# Altium schematic sheet Page3_MCU.SchDoc
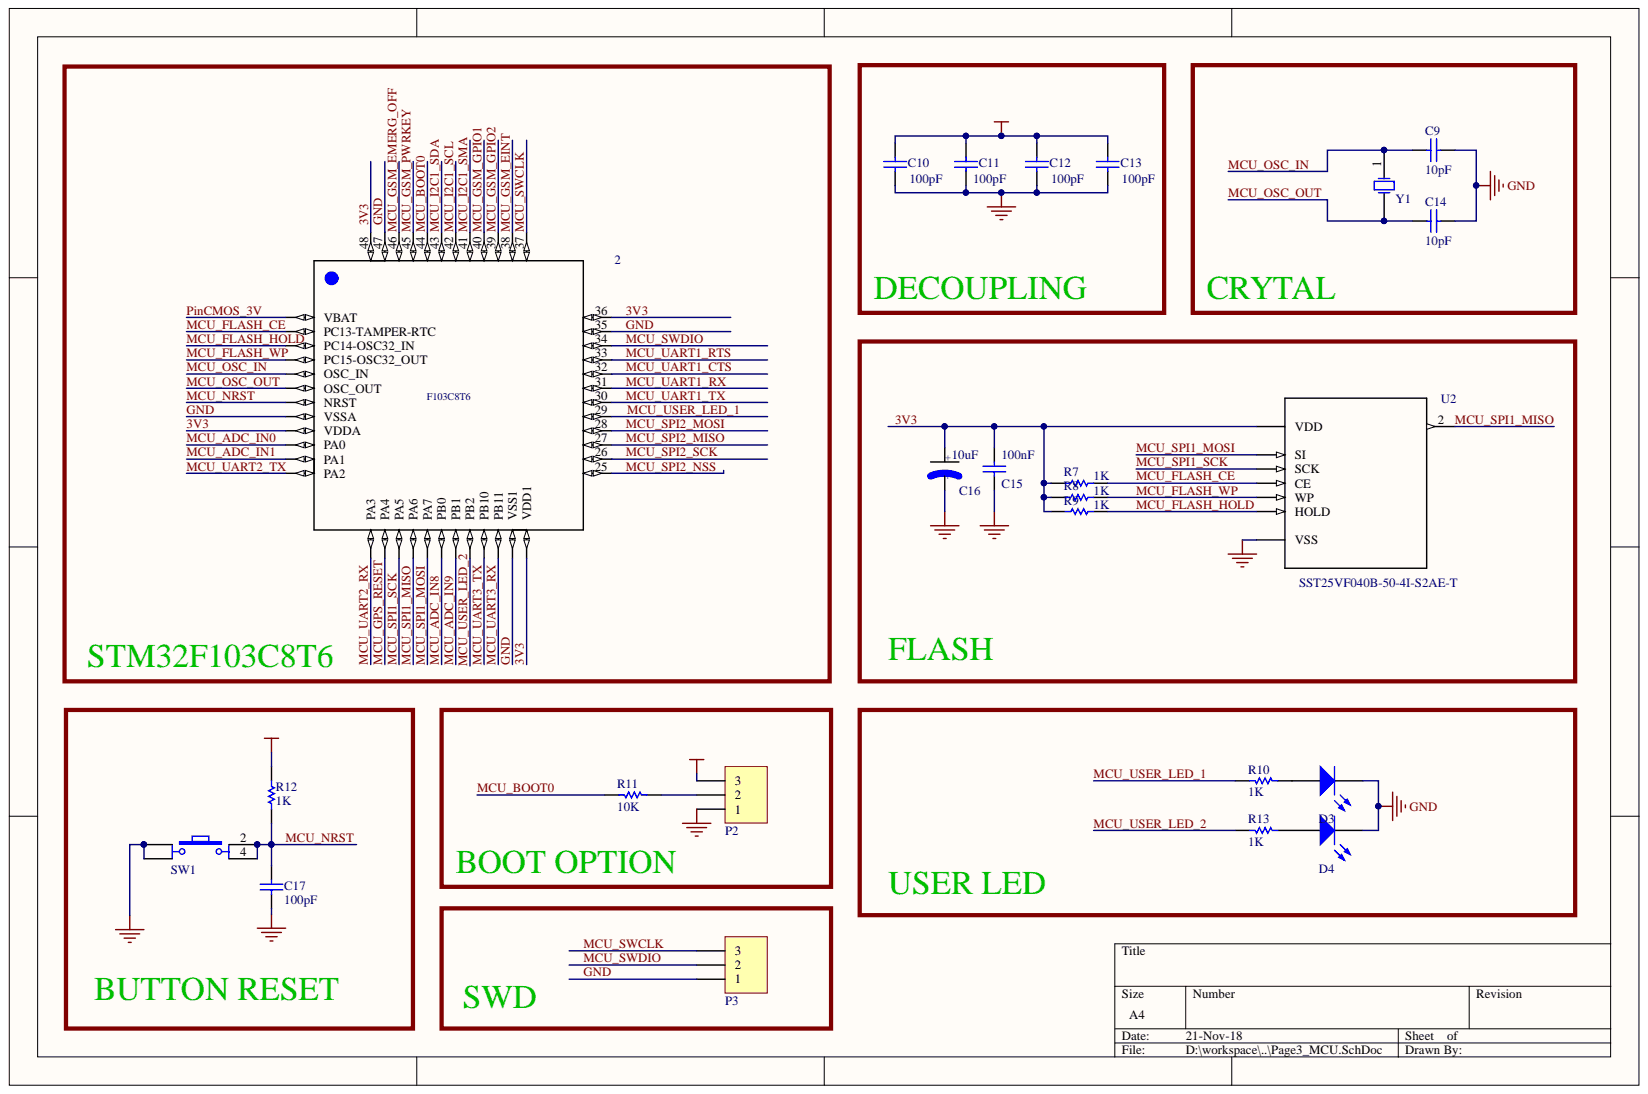
[diagram p0: Page3_MCU.SchDoc]

## Parts
2  STM32F103C8T6  [LQFP48_7X7MM_0.5MMPP]
C9  value 10pF  [CAP-SM-0603]  (hidden comment: Cap Non-Pol SMD)
C10  value 100pF  [CAP-SM-0603]  (hidden comment: Cap Non-Pol SMD)
C11  value 100pF  [CAP-SM-0603]  (hidden comment: Cap Non-Pol SMD)
C12  value 100pF  [CAP-SM-0603]  (hidden comment: Cap Non-Pol SMD)
C13  value 100pF  [CAP-SM-0603]  (hidden comment: Cap Non-Pol SMD)
C14  value 10pF  [CAP-SM-0603]  (hidden comment: Cap Non-Pol SMD)
C15  value 100nF  [CAP-SM-0603]  (hidden comment: Cap Non-Pol SMD)
C16  10uF  [AVX-A_TANTALUM-SMD-10UF-10V-10%(AVX-A)(Primary)]
C17  value 100pF  [CAP-SM-0603]  (hidden comment: Cap Non-Pol SMD)
D3  LED SMD  [LED_0603_Blue]  (value not drawn)
D4  LED SMD  [LED_0603_Blue]  (value not drawn)
P2  Header 3  [JP3]  (value not drawn)
P3  Header 3  [JP3]  (value not drawn)
R7  value 1K  [RES-SM-0603]  (hidden comment: RESISTOR SMD)
R8  value 1K  [RES-SM-0603]  (hidden comment: RESISTOR SMD)
R9  value 1K  [RES-SM-0603]  (hidden comment: RESISTOR SMD)
R10  value 1K  [RES-SM-0603]  (hidden comment: RESISTOR SMD)
R11  value 10K  [RES-SM-0603]  (hidden comment: RESISTOR SMD)
R12  value 1K  [RES-SM-0603]  (hidden comment: RESISTOR SMD)
R13  value 1K  [RES-SM-0603]  (hidden comment: RESISTOR SMD)
SW1  SW  [Tact SW 4 pin]
U2  SST25VF040B-50-4I-S2AE-T  [SOIC127P790X216-8N]
Y1  XTAL  [XTAL 32KN]  (value not drawn)

## Nets
3V3: 2.9(VDDA) 2.24(VDD1) 2.36 2.48 C10.2 C11.2 C12.2 C13.2 C15.2 C16.POS P2.3 R7.1 R8.1 R9.1 R12.2 U2.8(VDD)
GND: 2.8(VSSA) 2.23(VSS1) 2.35 2.47 C9.1 C10.1 C11.1 C12.1 C13.1 C14.1 C15.1 C16.NEG C17.1 D3.2 D4.2 P2.1 P3.1 SW1.1 SW1.3 U2.4(VSS)
MCU_ADC_IN0: 2.10(PA0)
MCU_ADC_IN1: 2.11(PA1)
MCU_ADC_IN8: 2.18(PB0)
MCU_ADC_IN9: 2.19(PB1)
MCU_BOOT0: 2.44 R11.2
MCU_FLASH_CE: 2.2(PC13-TAMPER-RTC) R7.2 U2.1(CE)
MCU_FLASH_HOLD: 2.3(PC14-OSC32_IN) R9.2 U2.7(HOLD)
MCU_FLASH_WP: 2.4(PC15-OSC32_OUT) R8.2 U2.3(WP)
MCU_GPS_RESET: 2.14(PA4)
MCU_GSM_EINT: 2.38
MCU_GSM_EMERG_OFF: 2.46
MCU_GSM_GPIO1: 2.40
MCU_GSM_GPIO2: 2.39
MCU_GSM_PWRKEY: 2.45
MCU_I2C1_SCL: 2.42
MCU_I2C1_SDA: 2.43
MCU_I2C1_SMA: 2.41
MCU_NRST: 2.7(NRST) C17.2 R12.1 SW1.2 SW1.4
MCU_OSC_IN: 2.5(OSC_IN) C9.2 Y1.1
MCU_OSC_OUT: 2.6(OSC_OUT) C14.2 Y1.2
MCU_SPI1_MISO: 2.16(PA6) U2.2
MCU_SPI1_MOSI: 2.17(PA7) U2.5(SI)
MCU_SPI1_SCK: 2.15(PA5) U2.6(SCK)
MCU_SPI2_MISO: 2.27
MCU_SPI2_MOSI: 2.28
MCU_SPI2_NSS: 2.25
MCU_SPI2_SCK: 2.26
MCU_SWCLK: 2.37 P3.3
MCU_SWDIO: 2.34 P3.2
MCU_UART1_CTS: 2.32
MCU_UART1_RTS: 2.33
MCU_UART1_RX: 2.31
MCU_UART1_TX: 2.30
MCU_UART2_RX: 2.13(PA3)
MCU_UART2_TX: 2.12(PA2)
MCU_UART3_RX: 2.22(PB11)
MCU_UART3_TX: 2.21(PB10)
MCU_USER_LED_1: 2.29 R10.1
MCU_USER_LED_2: 2.20(PB2) R13.1
PinCMOS_3V: 2.1(VBAT)
NetD3_1: D3.1 R10.2
NetD4_1: D4.1 R13.2
NetP2_2: P2.2 R11.1

## Library data of the parts
2 = STM32F103C8T6: Mainstream Performance line, ARM Cortex-M3 MCU with 64 Kbytes Flash, 72 MHz CPU, motor control, USB and CAN; MF: STMicroelectronics; Availability: Unavailable; Component Kind: Standard; Library Name: STM32F103C8T6.SchLib; MP: STM32F103C8T6; Package: LQFP-48 STMicroelectronics; Price: None; SnapEDA_Link: https://www.snapeda.com/parts/STM32F103C8T6/STMicroelectronics/view-part/?ref=snap
C9-C15 C17 = Cap Non-Pol SMD: Capacitor; Component Kind: Standard; Library Name: PIF_Library.IntLib
C16 = TANTALUM-SMD-10UF-10V-10%(AVX-A): Cap Tant Solid 10uF 10V A CASE 10% _3.2 X 1.6 X 1.6mm_ SMD 3216-18 3 Ohm 125Â°C T/R; MF: AVX Interconnect / Elco; MP: TAJA106K010RNJ; Availability: Unavailable; Component Kind: Standard; Library Name: TANTALUM-SMD-10UF-10V-10__AVX-A_.SchLib; Package: 1206 AVX Interconnect / Elco; Price: None; SnapEDA_Link: https://www.snapeda.com/parts/TAJA106K010RNJ/AVX%20Interconnect%20/%20Elco/view-part/?ref=snap
D3 D4 = LED SMD: LED; Component Kind: Standard; Library Name: PIF_Library.IntLib
P2 P3 = Header 3: Header, 3-Pin; Component Kind: Standard; LatestRevisionDate: 17-Jul-2002; LatestRevisionNote: Re-released for DXP Platform.; Library Name: PIF_Library.IntLib; Publisher: Altium Limited
R7-R13 = RESISTOR SMD: Resistor; Component Kind: Standard; Library Name: PIF_Library.IntLib
SW1 = Tact SW - 4Pin: Switch button 4 pin; Value: Button chip 4 pin; Component Kind: Standard; Library Name: PIF_Library.IntLib
U2 = SST25VF040B-50-4I-S2AE-T: 2.7V to 3.6V 4Mbit SPI Serial Flash, 8 SOIJ .208in T/R; MF: Microchip; Availability: Unavailable; Component Kind: Standard; Library Name: SST25VF040B-50-4I-S2AE-T.SchLib; MP: SST25VF040B-50-4I-S2AE-T; Package: SOIC-8 Microchip; Price: None; SnapEDA_Link: https://www.snapeda.com/parts/SST25VF040B-50-4I-S2AE-T/Microchip/view-part/?ref=snap
Y1 = XTAL: Crystal Oscillator; Component Kind: Standard; LatestRevisionDate: 17-Jul-2002; LatestRevisionNote: Re-released for DXP Platform.; Library Name: PIF_Library.IntLib; PackageDescription: Crystal, Thru-Hole; 2 Leads; Body 3.1 x 8.2 mm (Dia.xH); PackageReference: R38; Published: 8-Jun-2000; Publisher: Altium Limited
